# Altium schematic sheet KC4D_EXT_PHOTO_V1.2.SchDoc(KC4D_EXT_PHOTO_V1.2)
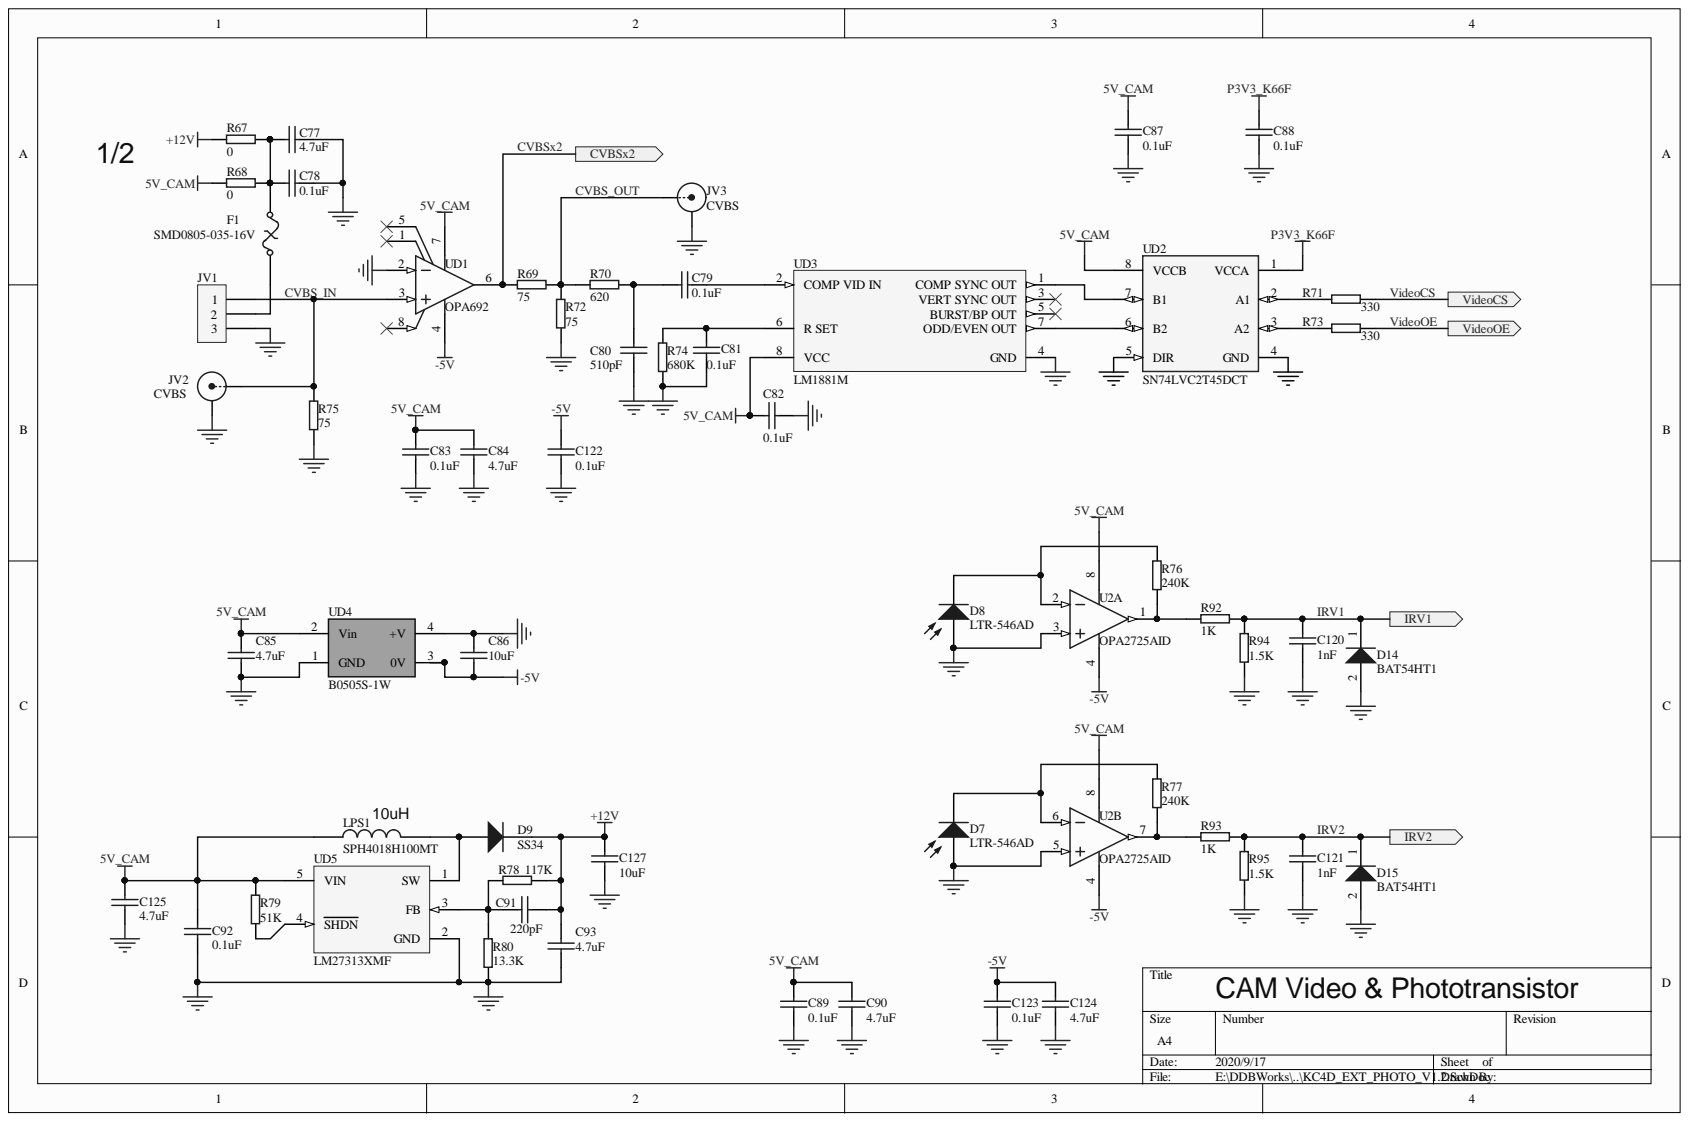
[diagram p0: KC4D_EXT_PHOTO_V1.2.SchDoc]

## Parts
C77  4.7uF  [C0603J]
C78  0.1uF  [C0603J]
C79  0.1uF  [C0603J]
C80  510pF  [C0603J]
C81  0.1uF  [C0603J]
C82  0.1uF  [C0603J]
C83  0.1uF  [C0603J]
C84  4.7uF  [C0603J]
C85  4.7uF  [C0805J]
C86  10uF  [C0805J]
C87  0.1uF  [C0603J]
C88  0.1uF  [C0603J]
C89  0.1uF  [C0603J]
C90  4.7uF  [C0603J]
C91  220pF  [C0603J]
C92  0.1uF  [C0603J]
C93  4.7uF  [C0805J]
C120  1nF  [C0603J]
C121  1nF  [C0603J]
C122  0.1uF  [C0603J]
C123  0.1uF  [C0603J]
C124  4.7uF  [C0603J]
C125  4.7uF  [C0603J]
C127  10uF  [C0805J]
D7  LTR-546AD  [LTR-546AD]
D8  LTR-546AD  [LTR-546AD]
D9  SS34  [SMAJ]
D14  BAT54HT1  [ONSC-SOD-323-2-477-02_V]
D15  BAT54HT1  [ONSC-SOD-323-2-477-02_V]
F1  SMD0805-035-16V  [F0805J]
JV1  JCAM  [PH2.0-3AW]  (value not drawn)
JV2  CVBS  [AV-8.4-3B]
JV3  CVBS  [AV-8.4-3B]
LPS1  SPH4018H100MT  [SWPA4026S]
R67  0  [R0805J]
R68  0  [R0805J]
R69  75  [R0805J]
R70  620  [R0603J]
R71  330  [R0603J]
R72  75  [R0805J]
R73  330  [R0603J]
R74  680K  [R0603J]
R75  75  [R0805J]
R76  240K  [R0603J]
R77  240K  [R0603J]
R78  117K  [R0603J]
R79  51K  [R0603J]
R80  13.3K  [R0603J]
R92  1K  [R0603J]
R93  1K  [R0603J]
R94  1.5K  [R0603J]
R95  1.5K  [R0603J]
U2  OPA2725AID  [TI-D8_N]  (parts A,B)
UD1  OPA692  [TI-D8_N]
UD2  SN74LVC2T45DCT  [DCT_SSOP_SM8]
UD3  LM1881M  [M08A_N]
UD4  B0505S-1W  [B0505S-1W]
UD5  LM27313XMF  [MF05A_N]

## Ports
CVBSx2, IRV1, IRV2, VideoCS, VideoOE

## Nets
5V_CAM: C82.2 C83.1 C84.1 C85.2 C87.1 C89.1 C90.1 C92.2 C125.1 LPS1.1 R68.1 R79.1 U2.8 UD1.7 UD2.8(VCCB) UD3.8(VCC) UD4.2(Vin) UD5.5(VIN)
+12V: C91.1 C93.2 C127.1 D9.2 R67.1 R78.2
-5V: C86.1 C122.1 C123.1 C124.1 U2.4 UD1.4 UD4.3(0V)
GND: C77.2 C78.2 C80.1 C81.1 C82.1 C83.2 C84.2 C85.1 C86.2 C87.2 C88.2 C89.2 C90.2 C92.1 C93.1 C120.2 C121.2 C122.2 C123.2 C124.2 C125.2 C127.2 D7.1 D8.1 D14.2 D15.2 JV1.3 JV2.2 JV3.2 R72.1 R74.1 R75.2 R80.2 R94.2 R95.2 U2.3 U2.5 UD1.2 UD2.4(GND) UD2.5(DIR) UD3.4(GND) UD4.1(GND) UD4.4(+V) UD5.2(GND)
P3V3_K66F: C88.1 UD2.1(VCCA)
CVBS_IN: JV1.1 JV2.1 R75.1 UD1.3
CVBS_OUT: JV3.1 R69.2 R70.1 R72.2
CVBSx2: R69.1 UD1.6 ; port CVBSx2
IRV1: C120.1 D14.1 R92.1 R94.1 ; port IRV1
IRV2: C121.1 D15.1 R93.1 R95.1 ; port IRV2
VideoCS: R71.1 ; port VideoCS
VideoOE: R73.1 ; port VideoOE
NetC77_1: C77.1 C78.1 F1.2 R67.2 R68.2
NetC79_1: C79.1 UD3.2(COMP_VID_IN)
NetC79_2: C79.2 C80.2 R70.2
NetC81_2: C81.2 R74.2 UD3.6(R_SET)
NetC91_2: C91.2 R78.1 R80.1 UD5.3(FB)
NetD7_2: D7.2 R77.2 U2.6
NetD8_2: D8.2 R76.2 U2.2
NetD9_1: D9.1 LPS1.2 UD5.1(SW)
NetF1_1: F1.1 JV1.2
NetR71_2: R71.2 UD2.2(A1)
NetR73_2: R73.2 UD2.3(A2)
NetR76_1: R76.1 R92.2 U2.1
NetR77_1: R77.1 R93.2 U2.7
NetR79_2: R79.2 UD5.4(SHDN)
NetUD2_6: UD2.6(B2) UD3.7(ODD/EVEN_OUT)
NetUD2_7: UD2.7(B1) UD3.1(COMP_SYNC_OUT)

Not connected: UD1 1 5 8; UD3 3(VERT_SYNC_OUT) 5(BURST/BP_OUT)

## Library data of the parts
C77-C93 C120-C125 C127 = CAP: PCB3D: 0805_0_0000_C; Part Field 4: 30pF; Part Field 3: Altium Limited; Part Field 2: July-2002: Re-released for DXP Platform.; Part Field 1: 8-Jun-2000; Component Kind: Standard
D7 D8 = Photo Sen: Photosensitive Diode; Published: 24-Mar-1999; PackageReference: PIN2; Library Name: Miscellaneous Devices.IntLib; LatestRevisionNote: Re-released for DXP Platform.; LatestRevisionDate: 17-Jul-2002; Component Kind: Standard; Publisher: Altium Limited
D9 = SMA(DO-214AC) SS54: 二极管; SuppliersPartNumber: C22452; Supplier: LC; Package: SMA(DO-214AC); Library Name: Miscellaneous Devices LC.IntLib; ComponentLink1URL: http://www.szlcsc.com/product/details_23178.html; ComponentLink1Description: 供应商链接; Component Kind: Standard
D14 D15 = BAT54HT1: Schottky Barrier Diode, 2-Pin SOD-323, Tape and Reel; Manufacturer: On Semiconductor; trr Max (ns): 5; IFSM Max (A): 0.6; Configuration: Single; Supplier Part Number 1: BAT54HT1OSCT-ND; Supplier 1: Digi-Key; RoHS: TRUE; PartNumber: BAT54HT1; Packing: Tape and Reel; PackageVersion: Rev. H, 03/2007; PackageReference: SOD-323-2-477-02; PackageDescription: 2-Pin SOD, Body 1.7 x 1.25 mm; Mounting Technology: Surface Mount; Library Name: ON Semi Schottky Diodes & Schottky Rectifiers.IntLib; DatasheetVersion: Rev. 6; ComponentLink3URL: http://www.onsemi.com/pub_link/Collateral/477-02.PDF.1; ComponentLink3Description: Package Specification; ComponentLink2URL: http://www.onsemi.cn/pub_link/Collateral/BAT54HT1-D.PDF; ComponentLink2Description: Datasheet; ComponentLink1URL: http://www.onsemi.com/; ComponentLink1Description: Manufacturer URL; Component Kind: Standard
F1 = Fuse Thermal: Thermal Fuse; Published: 24-Mar-1999; PackageReference: PIN-W2/E2.8; Library Name: Miscellaneous Devices.IntLib; LatestRevisionNote: Re-released for DXP Platform.; LatestRevisionDate: 17-Jul-2002; Component Kind: Standard; Publisher: Altium Limited
JV1 = CON3: Connector; Component Kind: Standard
JV2 JV3 = BNC: BNC Elbow Connector; Signal Integrity: Connector; Library Name: Miscellaneous Connectors.IntLib; LatestRevisionNote: Re-released for DXP Platform.; LatestRevisionDate: 17-Jul-2002; Component Kind: Standard; Publisher: Altium Limited
LPS1 = INDUCTOR: Component Kind: Standard
R67-R80 R92-R95 = Ra_DTE: PCB3D: 0805_0_0000_R; Library Name: DTE1.SchLib; Component Kind: Standard
U2 = OPA2725AID: Dual Very Low Noise, High-Speed, CMOS Operational Amplifier, 4 to 12 V, -40 to 125 degC, 8-pin SOIC (D8), Green (RoHS & no Sb/Br); Manufacturer: Texas Instruments; Vn at 1kHz(Typ)(nV/rtHz): 10; Vio (25C)(Max)(mV): 5; Total Supply Voltage(Min)(+5V is 5, +/-5V is 10): 4; Total Supply Voltage(Max)(+5V is 5, +/-5V is 10): 12; Sub Family: Precision Amplifier; Slew Rate(Typ)(V/us): 30; Number of Channels: 2; Iq per channel(Max)(mA): 5.5; IIB(Max)(pA): 200; CMRR(Min)(dB): 88; Supplier Part Number 3: 595-OPA2725AID; Supplier Part Number 2: 90H2238; Supplier Part Number 1: 296-17228-5-ND; Supplier 3: Mouser; Supplier 2: Newark; Supplier 1: Digi-Key; PartNumber: OPA2725AID; PackageVersion: 4040047-3/M, 06/2011; PackageReference: D8; PackageDescription: 8-Pin Plastic Small Outline, Body 4.9 x 3.9 mm, Pitch 1.27 mm; Offset Drift(Typ)(uV/C): 4; Mounting Technology: Surface Mount; Library Name: TI Amplifiers and Linear Operational Amplifier Precision Amplifier Part One.IntLib; Eco-Plan: Green (RoHS & no Sb/Br); DatasheetVersion: SBOS278B; ComponentLink3URL: http://www.ti.com/litv/pdf/msoi002j; ComponentLink3Description: Package Specification; ComponentLink2URL: http://www.ti.com/general/docs/lit/getliterature.tsp?genericPartNumber=OPA2725&fileType=pdf; ComponentLink2Description: Datasheet; ComponentLink1URL: http://www.ti.com/; ComponentLink1Description: Manufacturer URL; Component Kind: Standard; Code_JEDEC: MS-012
UD1 = OPA691ID: Wideband Current Feedback Operational Amplifier with Disable, 5 to 12 V, -40 to 85 degC, 8-pin SOIC (D8), Green (RoHS & no Sb/Br); Manufacturer: Texas Instruments; Vs(Min)(V): 5; Vs(Max)(V): 12; Vn at Flatband(Typ)(nV/rtHz): 1.7; Vio(Max)(mV): 2.5; THD (Fc is 1 MHz)(Typ)(dB): -80; Sub Family: High Output Current Amplifier (>=50mA), High Speed Amplifier (>=50MHz); Slew Rate(Typ)(V/us): 2100; Settling Time (0.1%)(Typ)(ns): 8; Number of Channels: 1; Iq per channel(Max)(mA): 4.5; Io(Typ)(mA): 190; Iib(Max)(nA): 45000; Diff Phase(deg): 0.02; Diff Gain(%): 0.07; BW @ Acl(MHz): 280; 3rd Harmonic(dBc): 93; 2nd Harmonic(dBc): 79; Supplier Part Number 3: 595-OPA691ID; Supplier Part Number 2: 75C5194; Supplier Part Number 1: OPA691ID-ND; Supplier 3: Mouser; Supplier 2: Newark; Supplier 1: Digi-Key; PartNumber: OPA691ID; PackageVersion: 4040047-3/M, 06/2011; PackageReference: D8; PackageDescription: 8-Pin Plastic Small Outline, Body 4.9 x 3.9 mm, Pitch 1.27 mm; Mounting Technology: Surface Mount; Library Name: TI Amplifiers and Linear Operational Amplifier High Speed.IntLib; Eco-Plan: Green (RoHS & no Sb/Br); DatasheetVersion: SBOS226D; ComponentLink3URL: http://www.ti.com/litv/pdf/msoi002j; ComponentLink3Description: Package Specification; ComponentLink2URL: http://www.ti.com/general/docs/lit/getliterature.tsp?genericPartNumber=OPA691&fileType=pdf; ComponentLink2Description: Datasheet; ComponentLink1URL: http://www.ti.com/; ComponentLink1Description: Manufacturer URL; Component Kind: Standard; Code_JEDEC: MS-012; Acl, min stable gain(V/V): 1; @ MHz: 5
UD2 = SN74LVC2T45DCTR: Component Kind: Standard; Library Name: DTE_LibS.SchLib
UD3 = LM1881M: Video Sync Separator; Manufacturer: National Semiconductor; PowerWise: N; PackageTopMarking: NSZXTT*LM*1881M; CompType: OtherParts; RoHS: O; Published: 4-Dec-2008; Pin Count: 8; PartNumber: LM1881M; PackageVersion: revL, Sep-2006; PackageReference: M08A; PackageDescription: SOIC Narrow, 4.9 x 3.9 x 1.45mm, 8 Lead, 1.27mm Pitch; Library Name: NSC OtherParts.IntLib; DigiKeyPartNumber: LM1881M-ND; DatasheetVersion: May-2006; ComponentLink3URL: http://www.national.com/packaging/folders/m08a.html; ComponentLink3Description: M08A Package Page; ComponentLink2URL: http://www.national.com/mpf/LM/LM1881.html; ComponentLink2Description: LM1881 Web Page; ComponentLink1URL: http://www.national.com/ds/LM/LM1881.pdf; ComponentLink1Description: LM1881 Datasheet; Component Kind: Standard; Code_JEDEC: MS-012-AA; Code_IPC: SOIC127P600-8; Publisher: Altium Limited
UD5 = LM27313XMF: 1.6 MHz Boost Converter With 30V Internal FET Switch, 5-pin SOT-23; Manufacturer: National Semiconductor; PowerWise: N; PackageTopMarking: SRPB*XTT; High-Reliability: Y; CompType: Switcher; RoHS: N; PinCount: 5; PartNumber: LM27313XMF; PackageVersion: revD, Aug-2008; PackageReference: MF05A; PackageDescription: Molded SOT-23, .115 x .063 x .040 in Body, 5 Lead, .0375 in Pitch; Library Name: National Semiconductor Switcher.IntLib; DatasheetVersion: Jul-2007; ComponentLink4URL: http://www.national.com/packaging/folders/mf05a.html; ComponentLink4Description: MF05A Package Page; ComponentLink3URL: http://www.national.com/pf/LM/LM27313.html; ComponentLink3Description: LM27313 Web Page; ComponentLink2URL: http://www.national.com/ds/LM/LM27313.pdf; ComponentLink2Description: LM27313 Datasheet; ComponentLink1URL: http://www.national.com/; ComponentLink1Description: Manufacturer URL; Component Kind: Standard
UD4 = B0505S-1W: Library Name: DTE1.SchLib; Component Kind: Standard
